# Altium schematic sheet Sheet2.SchDoc(Sheet2)
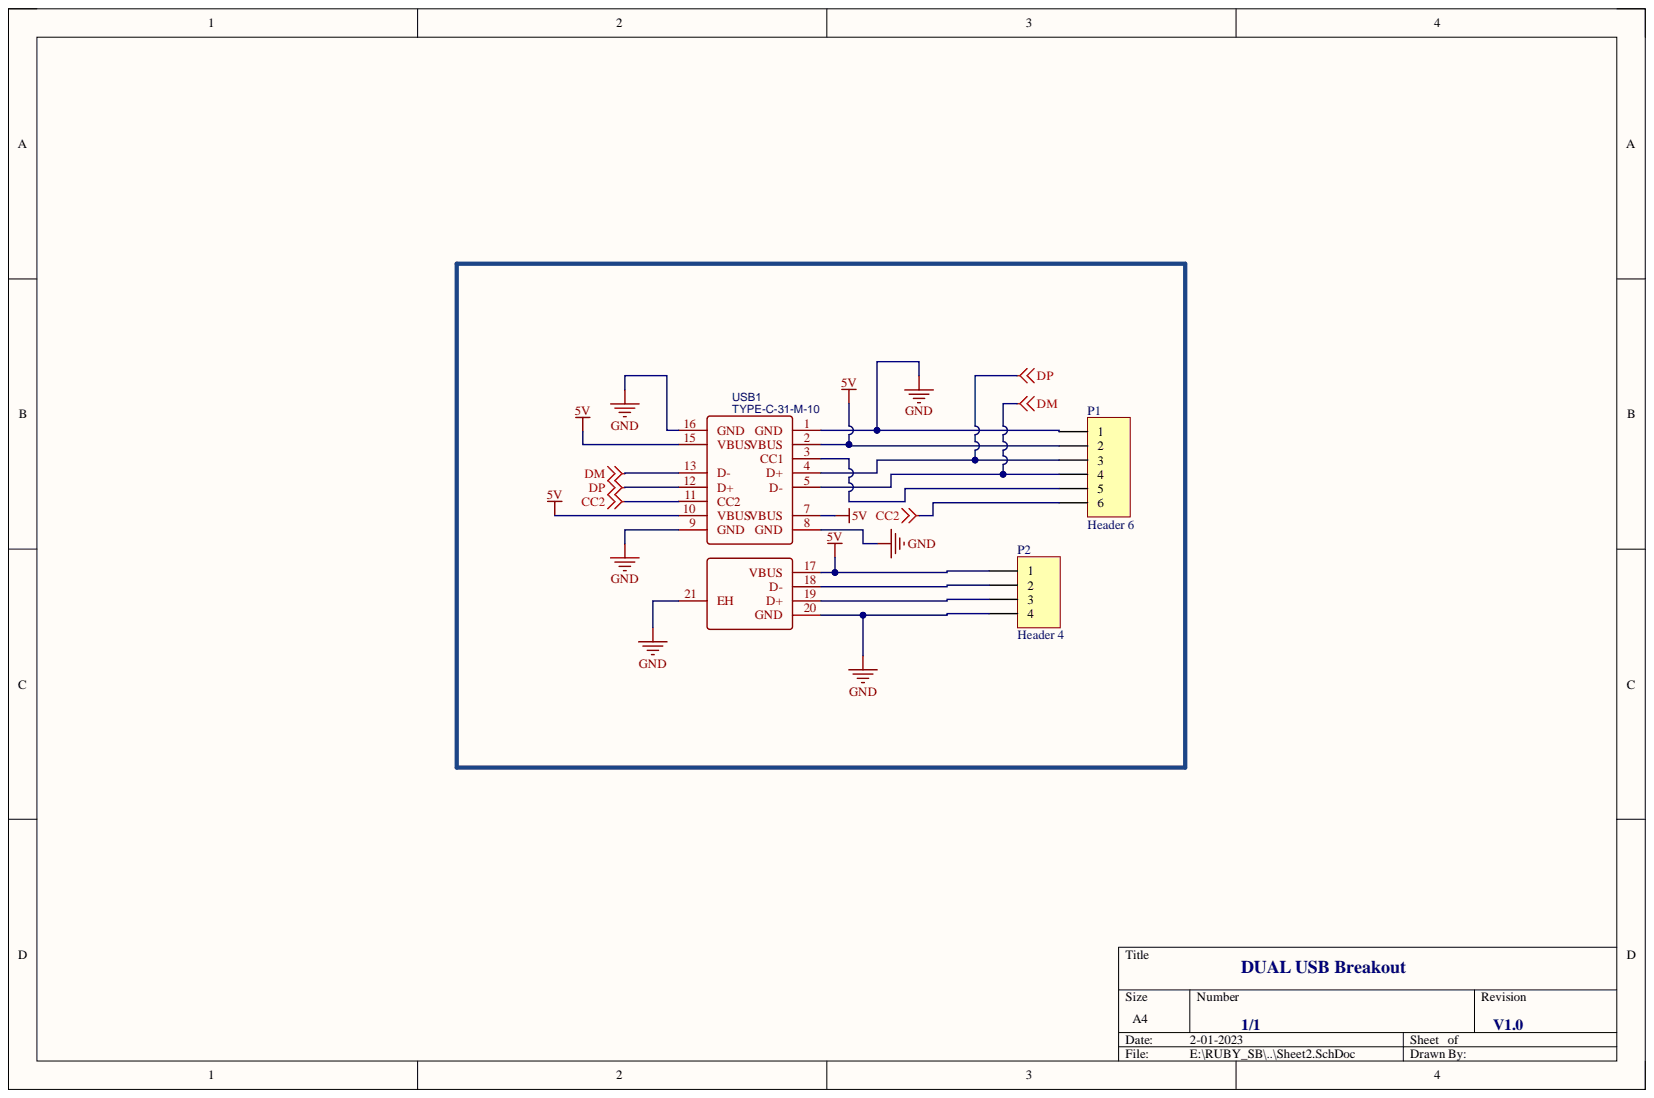
[diagram p0: Sheet2.SchDoc]

## Parts
P1  Header 6  [6P_2.54MM]
P2  Header 4  [4P_TH_2.54MM]
USB1  TYPE-C-31-M-10  [USBA  AND USBC]

## Nets
5V: P1.2 P2.1 USB1.2(VBUS) USB1.7(VBUS) USB1.10(VBUS) USB1.15(VBUS) USB1.17(VBUS)
CC2: P1.6 USB1.11(CC2)
DM: P1.4 USB1.5(D-) USB1.13(D-)
DP: P1.3 USB1.4(D+) USB1.12(D+)
GND: P1.1 P2.4 USB1.1(GND) USB1.8(GND) USB1.9(GND) USB1.16(GND) USB1.20(GND) USB1.21(EH)
NetP1_5: P1.5 USB1.3(CC1)
NetP2_2: P2.2 USB1.18(D-)
NetP2_3: P2.3 USB1.19(D+)

## Library data of the parts
P1 = Header 6: Header, 6-Pin; Component Kind: Standard; LatestRevisionDate: 17-Jul-2002; LatestRevisionNote: Re-released for DXP Platform.; Library Name: Miscellaneous Connectors.IntLib; Signal Integrity: Connector; Publisher: Altium Limited
P2 = Header 4: Header, 4-Pin; Component Kind: Standard; LatestRevisionDate: 17-Jul-2002; LatestRevisionNote: Re-released for DXP Platform.; Library Name: Miscellaneous Connectors.IntLib; Signal Integrity: Connector; Publisher: Altium Limited
USB1 = TYPE-C-31-M-10: BOM_Manufacturer: Korean Hroparts Elec; Contributor: LCSC; BOM_JLCPCB Part Class: Extended Part; BOM_Manufacturer Part: TYPE-C-31-M-10; BOM_Supplier: LCSC; BOM_Supplier Part: C223904; Component Kind: Standard; spicePre: U; spiceSymbolName: TYPE-C-31-M-10
